# Altium schematic sheet Magnetic-Charger.SchDoc(U_Magnetic-Charger)
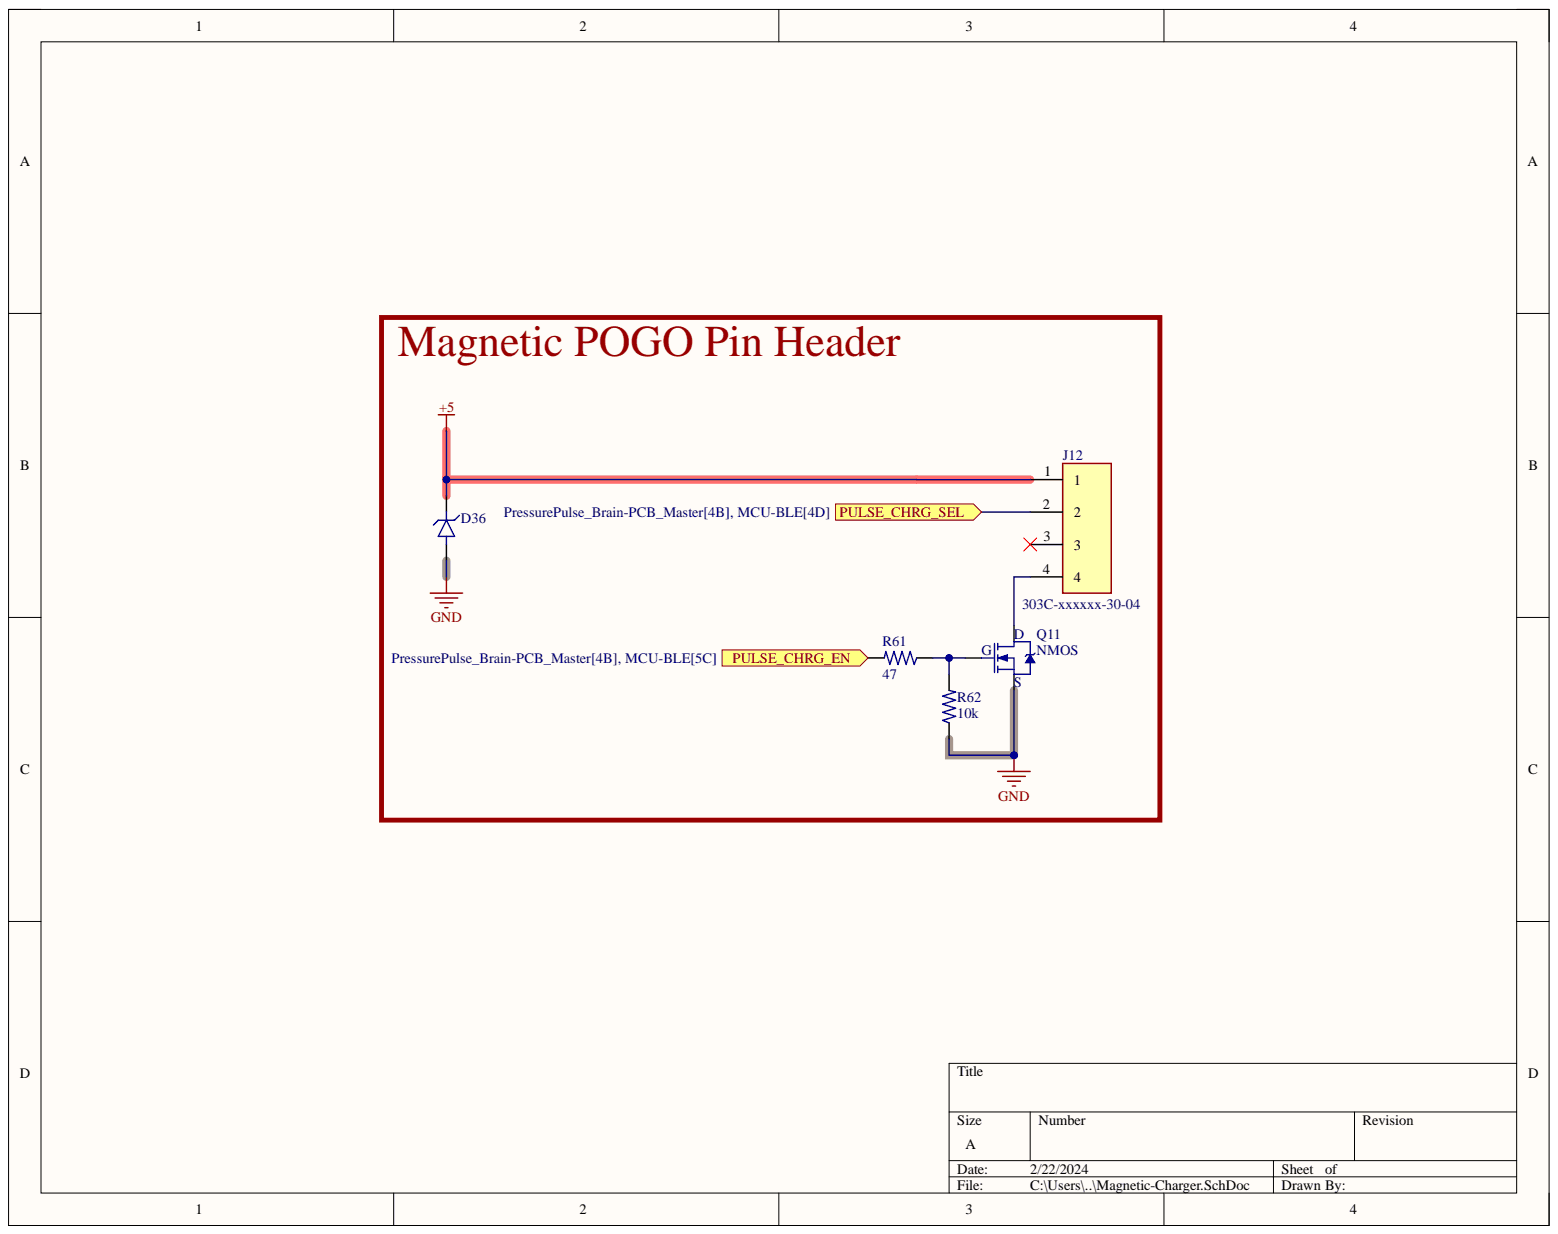
[diagram p0: Magnetic-Charger.SchDoc]

## Parts
D36  RCLAMP0521P.TCT  [RCLAMP0521P.TCT]  (value not drawn)
J12  303C-xxxxxx-30-04  [MAG POGO - 4 POS MALE]
Q11  NMOS  [SOT-23]
R61  value 47  [0402R]  (hidden comment: RES)
R62  value 10k  [0402R]  (hidden comment: RES)

## Ports
PULSE_CHRG_EN, PULSE_CHRG_SEL

## Nets
+5: D36.K J12.1
GND: D36.A Q11.S R62.1
PULSE_CHRG_EN: R61.2 ; port PULSE_CHRG_EN
PULSE_CHRG_SEL: J12.2 ; port PULSE_CHRG_SEL
NetJ12_3: J12.3
NetJ12_4: J12.4 Q11.D
NetQ11_G: Q11.G R61.1 R62.2

## Library data of the parts
D36 = TVS DIODE: 25V Clamp 4A (8/20µs) Ipp Tvs Diode Surface Mount SLP1006P2; MFR: Semtech Corporation; Type: SMD; Component Kind: Standard; Library Name: PressurePulse.SchLib; MFR PN: RCLAMP0521P.TCT; Value: RCLAMP0521P.TCT
J12 = 303C-xxxxxx-30-04: MAGNETIC POGO 4POS; MFR: ATTEND Technology; MFR PN: 303C-321018-30-04; Value: DNS; Component Kind: Standard; Library Name: PressurePulse.SchLib
Q11 = NMOS: 100V 1.6A 1.3W 220mΩ@10V,1.6A N Channel SOT-23  MOSFETs ROHS; MFR: Infineon; MFR PN: IRLML0100TRPBF; Value: IRLML0100TRPBF; Component Kind: Standard; JLCPCB PN: C53658; Library Name: PressurePulse.SchLib
R61 R62 = RES: Resistor; Component Kind: Standard; Library Name: PressurePulse.SchLib
